# Altium schematic sheet meltdown_protection.SchDoc
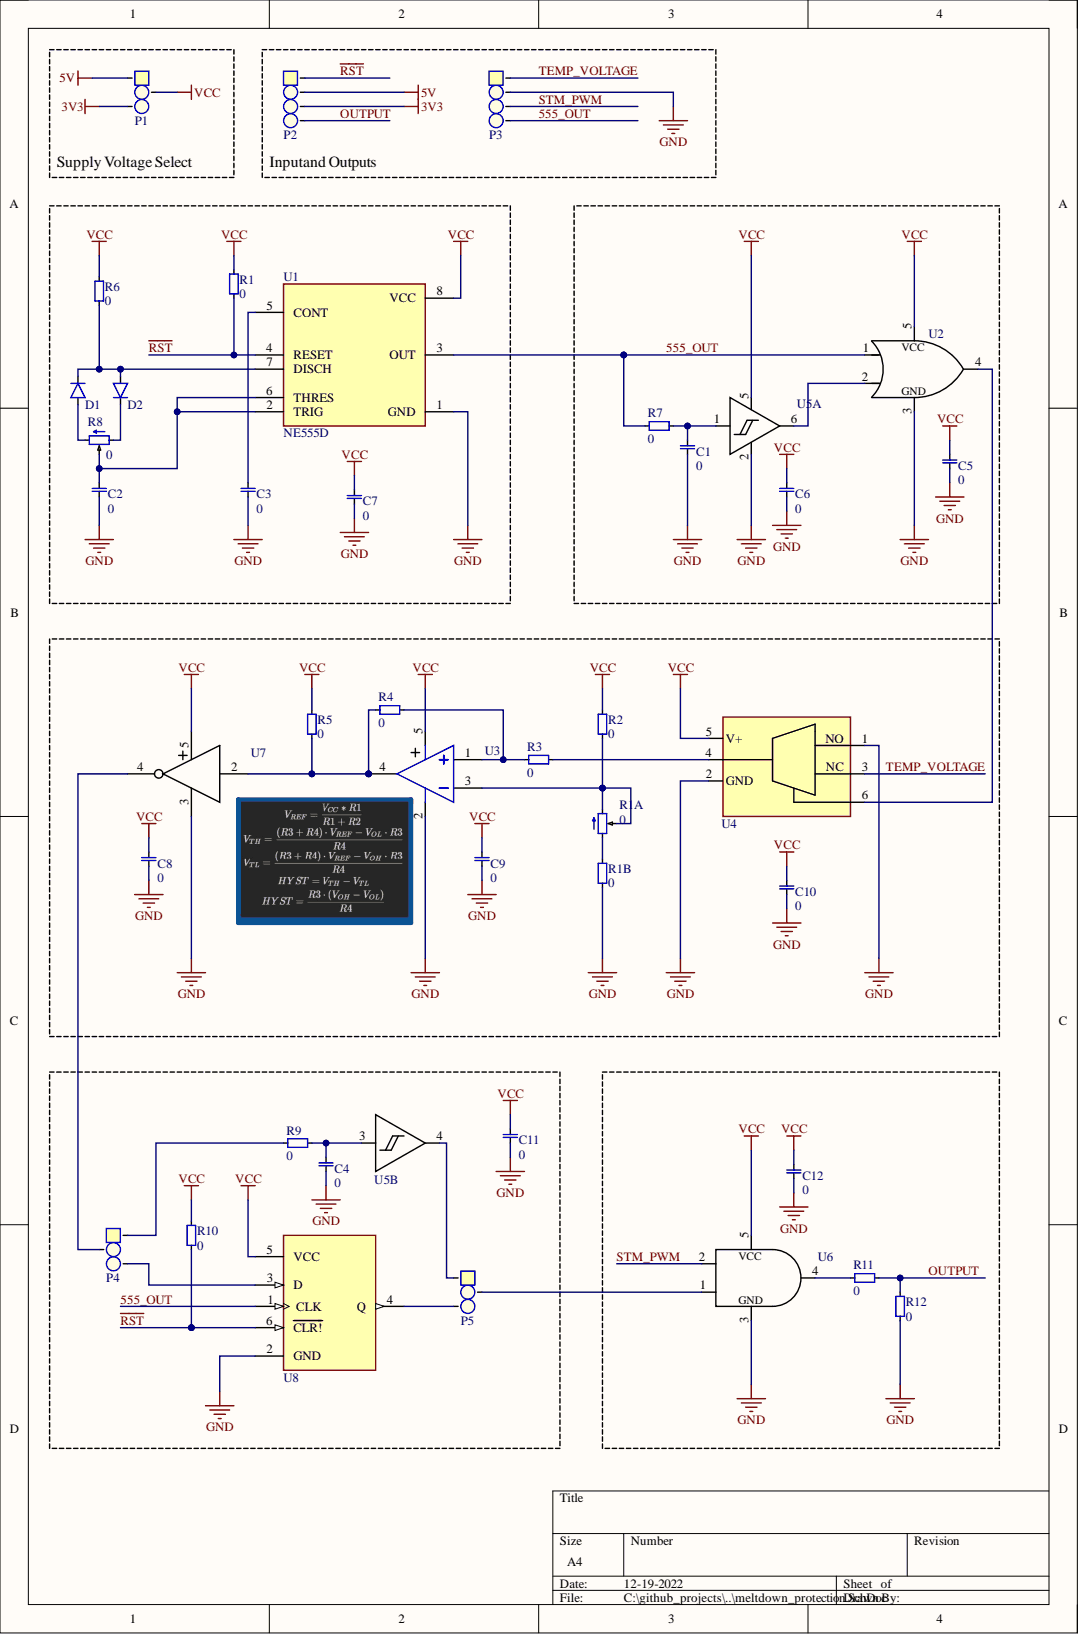
[diagram p0: meltdown_protection.SchDoc]

## Parts
C1  value 0  [0603 cap]  (hidden comment: 0603, capacitor)
C2  value 0  [0603 cap]  (hidden comment: 0603, capacitor)
C3  value 0  [0603 cap]  (hidden comment: 0603, capacitor)
C4  value 0  [0603 cap]  (hidden comment: 0603, capacitor)
C5  value 0  [0603 cap]  (hidden comment: 0603, capacitor)
C6  value 0  [0603 cap]  (hidden comment: 0603, capacitor)
C7  value 0  [0603 cap]  (hidden comment: 0603, capacitor)
C8  value 0  [0603 cap]  (hidden comment: 0603, capacitor)
C9  value 0  [0603 cap]  (hidden comment: 0603, capacitor)
C10  value 0  [0603 cap]  (hidden comment: 0603, capacitor)
C11  value 0  [0603 cap]  (hidden comment: 0603, capacitor)
C12  value 0  [0603 cap]  (hidden comment: 0603, capacitor)
D1  Diode, SMD  [SOD323]  (value not drawn)
D2  Diode, SMD  [SOD323]  (value not drawn)
P1  1x3 Pin Header Mux  [padThree_L]  (value not drawn)
P2  4x1 Pin Header  [padFour_L]  (value not drawn)
P3  4x1 Pin Header  [padFour_L]  (value not drawn)
P4  1x3 Pin Header Mux  [padThree_L]  (value not drawn)
P5  1x3 Pin Header Mux  [padThree_L]  (value not drawn)
R1  value 0  [0603]  (hidden comment: 0603, resistor)
R1A  value 0  [PV36W104C01B00]  (hidden comment: Pot)
R1B  value 0  [0603]  (hidden comment: 0603, resistor)
R2  value 0  [0603]  (hidden comment: 0603, resistor)
R3  value 0  [0603]  (hidden comment: 0603, resistor)
R4  value 0  [0603]  (hidden comment: 0603, resistor)
R5  value 0  [0603]  (hidden comment: 0603, resistor)
R6  value 0  [0603]  (hidden comment: 0603, resistor)
R7  value 0  [0603]  (hidden comment: 0603, resistor)
R8  value 0  [PV36W104C01B00]  (hidden comment: Pot)
R9  value 0  [0603]  (hidden comment: 0603, resistor)
R10  value 0  [0603]  (hidden comment: 0603, resistor)
R11  value 0  [0603]  (hidden comment: 0603, resistor)
R12  value 0  [0603]  (hidden comment: 0603, resistor)
U1  NE555D  [SOIC8]
U2  SN74LVC1G32DBVT  [SOT23-5]  (value not drawn)
U3  MCP6V66UT-E/LTY  [SC70P210X110-5N]  (value not drawn)
U4  TS5A3159DCKR  [SOT65P210X110-6N]  (value not drawn)
U5  SN74LVC2G17DBVT  [SOT95P280X145-6N]  (value not drawn)  (parts A,B)
U6  SN74LVC1G08DBVR  [SOT95P280X145-5N]  (value not drawn)
U7  SN74LVC1G04DBVR  [SOT95P280X145-5N]  (value not drawn)
U8  SN74LVC1G175DBVR  [SOT95P280X145-6N]  (value not drawn)

## Nets
3V3: P1.3 P2.3
5V: P1.1 P2.2
GND: C1.0 C2.0 C3.0 C4.0 C5.0 C6.0 C7.0 C8.0 C9.0 C10.0 C11.0 C12.0 P3.2 R1B.1 R12.1 U1.1(GND) U2.3 U3.2 U4.1 U4.2(GND) U5.2 U6.3 U7.3 U8.2(GND)
VCC: C5.1 C6.1 C7.1 C8.1 C9.1 C10.1 C11.1 C12.1 P1.2 R1.0 R2.0 R5.1 R6.0 R10.1 U1.8(VCC) U2.5 U3.5 U4.5(V+) U5.5 U6.5 U7.5 U8.5(VCC)
555_OUT: P3.4 R7.1 U1.3(OUT) U2.1 U8.1(CLK)
OUTPUT: P2.4 R11.0 R12.0
~{RST}: P2.1 R1.1 R10.0 U1.4(RESET) U8.6(CLR!)
STM_PWM: P3.3 U6.2
TEMP_VOLTAGE: P3.1 U4.3
NetC1_1: C1.1 R7.0 U5.1
NetC2_1: C2.1 R8.2 U1.2(TRIG) U1.6(THRES)
NetC3_1: C3.1 U1.5(CONT)
NetC4_1: C4.1 R9.0 U5.3
NetD1_1: D1.1 D2.2 R6.1 U1.7(DISCH)
NetD1_2: D1.2 R8.3
NetD2_1: D2.1 R8.1
NetP4_1: P4.1 R9.1
NetP4_2: P4.2 U7.4
NetP4_3: P4.3 U8.3(D)
NetP5_1: P5.1 U5.4
NetP5_2: P5.2 U6.1
NetP5_3: P5.3 U8.4(Q)
NetR1A_1: R1A.1 R1B.0
NetR1A_2: R1A.2 R1A.3 R2.1 U3.3
NetR3_0: R3.0 U4.4
NetR3_1: R3.1 R4.0 U3.1
NetR4_1: R4.1 R5.0 U3.4 U7.2
NetR11_1: R11.1 U6.4
NetU2_2: U2.2 U5.6
NetU2_4: U2.4 U4.6

## Library data of the parts
P1 P4 P5 = 1x3 Pin Header Mux: 2.54 mm; Component Kind: Standard; Value: 1x3 Pin Header; Library Name: jclib.IntLib
P2 P3 = 1x4 Pin Header: 2.54 mm; Component Kind: Standard; Value: 4x1 Pin Header; Library Name: jclib.IntLib
U2 = SN74LVC1G32DBVT: Single 2-input, 1.65-V to 5.5-V OR gate; MF: Texas Instruments; Availability: In Stock; Check_prices: https://www.snapeda.com/parts/SN74LVC1G32DBVT/Texas+Instruments/view-part/?ref=eda; Component Kind: Standard; MP: SN74LVC1G32DBVT; Package: SOT-23-5 Texas Instruments; Price: None; Purchase-URL: https://pricing.snapeda.com/search/part/SN74LVC1G32DBVT/?ref=eda; SnapEDA_Link: https://www.snapeda.com/parts/SN74LVC1G32DBVT/Texas+Instruments/view-part/?ref=snap; Library Name: jclib.IntLib
U3 = MCP6V66UT-E/LTY: Linear High Precision Op Amps-Chopper Stabilized, 1000kHz, 1.8Vto5.5V, SC70-5, RoHS; Manufacturer: Microchip; Category: Amplifiers - Op Amps, Buffer, Instrumentation; Component Kind: Standard; Manufacturer Part Number: MCP6V66UT-E/LTY; Pricing 1: 3000=0,93150 (USD); RoHS: No; Stock 1: 50; Supplier 1: Avnet; Supplier Part Number 1: MCP6V66UT-E/LTY; Library Name: jclib.IntLib
U4 = TS5A3159DCKR: 1-?, 5-V, 2:1 (SPDT), 1-channel analog switch 6-SC70 -40 to 85; MF: Texas Instruments; Availability: In Stock; Check_prices: https://www.snapeda.com/parts/TS5A3159DCKR/Texas%20Instruments/view-part/303403/?ref=eda; Component Kind: Standard; MP: TS5A3159DCKR; Package: SOT-SC70-6 Texas Instruments; Price: None; Purchase-URL: https://pricing.snapeda.com/search/part/TS5A3159DCKR/?ref=eda; SnapEDA_Link: https://www.snapeda.com/parts/TS5A3159DCKR/Texas%20Instruments/view-part/303403/?ref=snap; Library Name: jclib.IntLib
U5 = SN74LVC2G17DBVT: Dual Schmitt-Trigger Buffer; MF: Texas Instruments; Availability: Unavailable; Component Kind: Standard; DigiKey-Purchase-URL: https://snapeda.com/shop?store=DigiKey&id=466474; Mouser-Purchase-URL: https://snapeda.com/shop?store=Mouser&id=466474; MP: SN74LVC2G17DBVT; Package: SOT-23-6 Texas Instruments; Price: None; SnapEDA_Link: https://www.snapeda.com/parts/SN74LVC2G17DBVT/Texas%20Instruments/view-part/466474/?ref=snap; Texas_Instruments-Purchase-URL: https://snapeda.com/shop?store=Texas+Instruments&id=466474; Library Name: jclib.SchLib
U6 = SN74LVC1G08DBVR: Single 2-Input Positive-AND Gate 5-SOT-23 -40 to 125; MF: Texas Instruments; Availability: Unavailable; Component Kind: Standard; MP: SN74LVC1G08DBVR; Package: SOT-23-5 Texas Instruments; Price: None; SnapEDA_Link: https://www.snapeda.com/parts/SN74LVC1G08DBVR/Texas%20Instruments/view-part/78735/?ref=snap; Texas_Instruments-Purchase-URL: https://snapeda.com/shop?store=Texas+Instruments&id=78735; Library Name: jclib.IntLib
U7 = SN74LVC1G04DBVR: Single Inverter 5-SOT-23 -40 to 125; Availability: Unavailable; Component Kind: Standard; MP: SN74LVC1G04DBVR; Package: None; Price: None; Library Name: jclib.IntLib
U8 = SN74LVC1G175DBVR: Single D-Type Flip-Flop with Asynchronous Clear 6-SOT-23 -40 to 125; MF: Texas Instruments; Check_prices: https://www.snapeda.com/parts/SN74LVC1G175DBVR/Texas%20Instruments/view-part/473666/?ref=eda; Component Kind: Standard; Digi-Key_Part_Number: 296-17617-1-ND; MP: SN74LVC1G175DBVR; Package: SOT-23-6 Texas Instruments; Purchase-URL: https://pricing.snapeda.com/search/part/SN74LVC1G175DBVR/?ref=eda; SnapEDA_Link: https://www.snapeda.com/parts/SN74LVC1G175DBVR/Texas%20Instruments/view-part/473666/?ref=snap; Library Name: jclib.IntLib
C1-C12 = 0603, capacitor: Component Kind: Standard; Library Name: jclib.IntLib
D1 D2 = Diode, SMD: Component Kind: Standard; Library Name: jclib.SchLib
R1 R1B R2-R7 R9-R12 = 0603, resistor: Component Kind: Standard; Library Name: jclib.IntLib
R1A R8 = Pot: Component Kind: Standard; Library Name: jclib.IntLib
U1 = NE555D: Availability: Unavailable; Component Kind: Standard; MP: NE555D; Package: None; Price: None; Library Name: jclib.SchLib
